# Altium schematic sheet Connector.SchDoc(Connector)
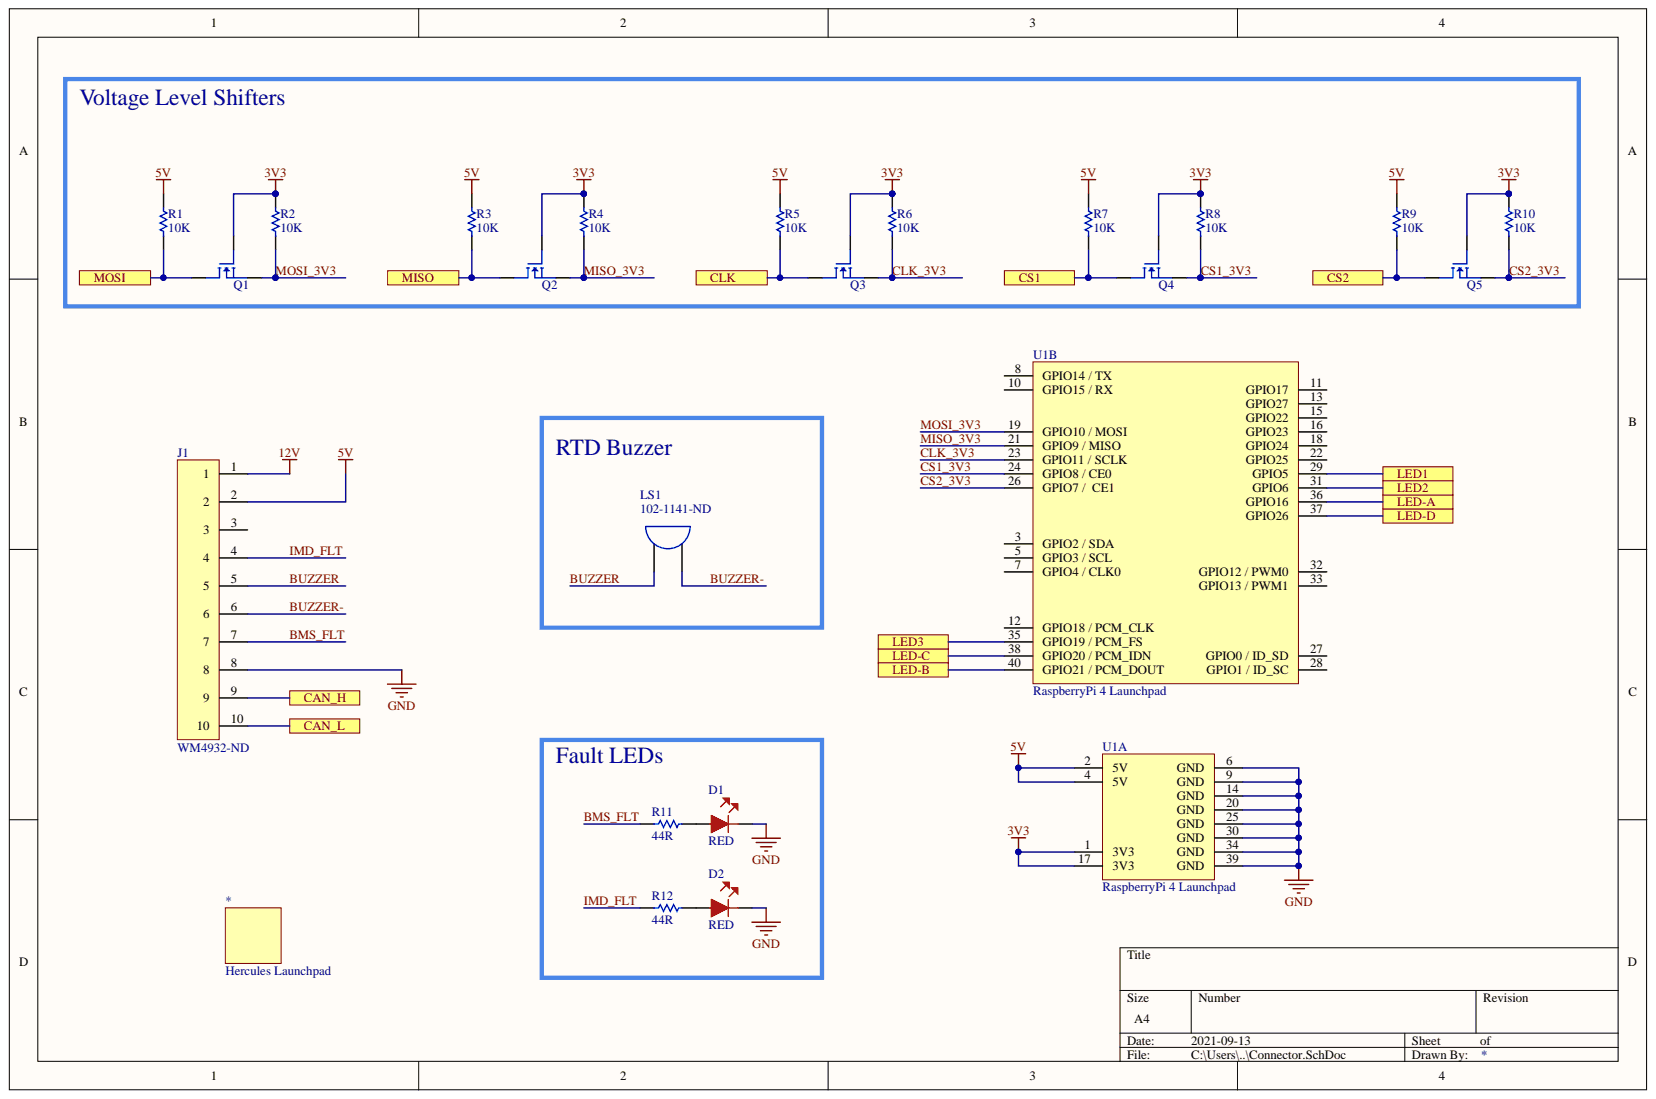
[diagram p0: Connector.SchDoc]

## Parts
*  Hercules Launchpad  [RECEp]
D1  RED  [LED]
D2  RED  [LED]
J1  WM4932-ND  [10PIN]
LS1  102-1141-ND  [Buzzer]
Q1  DMN6140L-13DICT-ND  [SOT-23-3]  (value not drawn)
Q2  DMN6140L-13DICT-ND  [SOT-23-3]  (value not drawn)
Q3  DMN6140L-13DICT-ND  [SOT-23-3]  (value not drawn)
Q4  DMN6140L-13DICT-ND  [SOT-23-3]  (value not drawn)
Q5  DMN6140L-13DICT-ND  [SOT-23-3]  (value not drawn)
R1  10K  [RES-0603]
R2  10K  [RES-0603]
R3  10K  [RES-0603]
R4  10K  [RES-0603]
R5  10K  [RES-0603]
R6  10K  [RES-0603]
R7  10K  [RES-0603]
R8  10K  [RES-0603]
R9  10K  [RES-0603]
R10  10K  [RES-0603]
R11  44R  [RES-0603]
R12  44R  [RES-0603]
U1  RaspberryPi 4 Launchpad  [Rpi Connector]  (parts A,B)

## Ports
CAN_H, CAN_L, CLK, CS1, CS2, LED1, LED2, LED3, LED-A, LED-B, LED-C, LED-D, MISO, MOSI

## Nets
3V3: Q1.1 Q2.1 Q3.1 Q4.1 Q5.1 R2.2 R4.2 R6.2 R8.2 R10.2 U1.1(3V3) U1.17(3V3)
5V: J1.2 R1.2 R3.2 R5.2 R7.2 R9.2 U1.2(5V) U1.4(5V)
12V: J1.1
GND: D1.2 D2.2 J1.8 U1.6(GND) U1.9(GND) U1.14(GND) U1.20(GND) U1.25(GND) U1.30(GND) U1.34(GND) U1.39(GND)
BMS_FLT: J1.7 R11.1
BUZZER: J1.5 LS1.1
BUZZER-: J1.6 LS1.2
CAN_H: J1.9 ; port CAN_H
CAN_L: J1.10 ; port CAN_L
CLK: Q3.2 R5.1 ; port CLK
CLK_3V3: Q3.3 R6.1 U1.23(GPIO11_/_SCLK)
CS1: Q4.2 R7.1 ; port CS1
CS1_3V3: Q4.3 R8.1 U1.24(GPIO8_/_CE0)
CS2: Q5.2 R9.1 ; port CS2
CS2_3V3: Q5.3 R10.1 U1.26(GPIO7_/)
IMD_FLT: J1.4 R12.1
LED1: U1.29(GPIO5) ; port LED1
LED2: U1.31(GPIO6) ; port LED2
LED3: U1.35(GPIO19_/_PCM_FS) ; port LED3
LED-A: U1.36(GPIO16) ; port LED-A
LED-B: U1.40(GPIO21_/_PCM_DOUT) ; port LED-B
LED-C: U1.38(GPIO20_/_PCM_IDN) ; port LED-C
LED-D: U1.37(GPIO26) ; port LED-D
MISO: Q2.2 R3.1 ; port MISO
MISO_3V3: Q2.3 R4.1 U1.21(GPIO9_/_MISO)
MOSI: Q1.2 R1.1 ; port MOSI
MOSI_3V3: Q1.3 R2.1 U1.19(GPIO10_/_MOSI)
NetD1_1: D1.1 R11.2
NetD2_1: D2.1 R12.2

Not connected: J1 3; U1 3(GPIO2_/_SDA) 5(GPIO3_/_SCL) 7(GPIO4_/_CLK0) 8(GPIO14_/_TX) 10(GPIO15_/_RX) 11(GPIO17) 12(GPIO18_/_PCM_CLK) 13(GPIO27) 15(GPIO22) 16(GPIO23) 18(GPIO24) 22(GPIO25) 27(GPIO0_/_ID_SD) 28(GPIO1_/_ID_SC) 32(GPIO12_/_PWM0) 33(GPIO13_/_PWM1)

## Library data of the parts
* = Recep: Component Kind: Standard; Library Name: WorkshopSlib.SchLib
D1 D2 = RED_LED: 2835 PLCC2 RED WLD: 620NM TYP. 1; Manufacturer Part Number: QBHP686-RH; Manufacturer: QT-Brightek; Viewing Angle: 120°; Test Current: 150mA; Lens Transparency: Clear; Lens Style: Flat; Lens Color: Colorless; Forward Voltage: 2.4V; Width: 2.8mm; Supplier Part Number 1: 1516-1369-6-ND; Supplier 1: Digi-Key; Stock 1: 3207; Pricing 1: 1=0.85, 10=0.553, 100=0.3625, 1000=0.2862 (USD); Packaging: Tape and Reel; Mount: Surface Mount; Length: 3.1mm; Height: 1mm; Dominant Wavelength: 620nm; ComponentLink1URL: http://www.qt-brightek.com/datasheet/QBHP686-XXH Series.pdf?src-supplier=Digi-Key; ComponentLink1Description: http://www.qt-brightek.com/datasheet/QBHP686-XXH Series.pdf?src-supplier=Digi-Key; Component Kind: Standard; Color: Red; Case Code (Imperial): 1113; Case/Package: 1113; Library Name: BrakeLight.SchLib
J1 = 10PIN: CONN HEADER R/A 10POS 2.54MM; Manufacturer Part Number: 0705530044; Manufacturer: Molex; Number of Positions: 10; Gender: Male; Contact Resistance: 30mR; Connector Type: Male Pin; Termination: Solder; Supplier Part Number 1: WM4932-ND; Supplier 1: Digi-Key; Stock 1: 1173; Pricing 1: 1=2.61, 10=2.367, 100=2.0288, 500=1.69068, 1000=1.44915, 5000=1.28491 (USD); Pitch: 2.54mm; Packaging: Rail/Tube; Orientation: Right Angle; Number of Rows: 1; Number of Contacts: 10; Mount: Through Hole; Min Operating Temperature: -40°C; Max Operating Temperature: 105°C; Library Name: Connectors.SchLib; Length: 27.95mm; Housing Material: Polyester; Housing Color: Black; Depth: 13.59mm; Contact Plating: Tin; Contact Mating Length: 0.24inch; Contact Material: Bronze; ComponentLink1URL: http://www.molex.com/webdocs/datasheets/pdf/en-us/0705530044_PCB_HEADERS.pdf?src-supplier=Avnet; ComponentLink1Description: http://www.molex.com/webdocs/datasheets/pdf/en-us/0705530044_PCB_HEADERS.pdf?src-supplier=Avnet; Component Kind: Standard; Color: Black
LS1 = Buzzer: AUDIO PIEZO TRANSDUCER 30V TH; Manufacturer: CUI; Washable: No; Voltage Rating: 10V; Self Resonant Frequency: 2kHz; Operating Supply Current: 8mA; Capacitance: 28nF; Termination: PC Pins; Supplier Part Number 1: 102-1141-ND; Supplier 1: Digi-Key; Stock 1: 11267; Pricing 1: 1=1.91, 10=1.588, 25=1.468, 50=1.3806, 100=1.2963, 500=1.11268, 1000=1.0621 (USD); Packaging: Bulk; Mount: Through Hole; Min Operating Temperature: -30°C; Max Operating Temperature: 85°C; Manufacturer Part Number: CEP-1116; Library Name: Misc.SchLib; Lead Free: Lead Free; Height: 10.5mm; Diameter: 30mm; Component Kind: Standard; Case/Package: Radial
Q1-Q5 = N-FET-60V-1.6A: MOSFET N-CH 60V 1.6A SOT23; Manufacturer: Diodes; Rds On Max: 140mR; Max Power Dissipation: 700mW; Input Capacitance: 315pF; Supplier Part Number 1: DMN6140L-13DIDKR-ND; Supplier 1: Digi-Key; Stock 1: 274948; Pricing 1: 1=0.34, 10=0.256, 25=0.224, 100=0.1217, 250=0.12104, 500=0.09926, 1000=0.07365, 2500=0.06468, 5000=0.05924 (USD); Packaging: Cut Tape; Package Quantity: 10000; Mount: Surface Mount; Min Operating Temperature: -55°C; Max Operating Temperature: 150°C; Manufacturer Part Number: DMN6140L-13; Library Name: Transistors.SchLib; Drain to Source Voltage (Vdss): 60V; Continuous Drain Current (ID): 1.6A; ComponentLink1URL: https://www.diodes.com/assets/Datasheets/DMN6140L.pdf?src-supplier=Digi-Key; ComponentLink1Description: https://www.diodes.com/assets/Datasheets/DMN6140L.pdf?src-supplier=Digi-Key; Component Kind: Standard; Case/Package: TO-236-3
R1-R10 = 0603-10KR: RES SMD 10K OHM 5% 1/10W 0603; Manufacturer Part Number: RC0603JR-0710KL; Manufacturer: Yageo; Voltage Rating (DC): 50V; Temperature Coefficient: 100ppm/°C; Resistance: 10kR; Power Rating: 100mW; Width: 0.031inch; Voltage Rating: 50V; Tolerance: 5%; Termination: SMD/SMT; Supplier Part Number 1: 311-10KGRDKR-ND; Supplier 1: Digi-Key; Stock 1: 3006558; REACH SVHC: Unknown; Radiation Hardening: No; Pricing 1: 1=0.1, 10=0.019, 100=0.0077, 1000=0.00347, 2500=0.00301 (USD); Packaging: Tape and Reel; Number of Terminations: 2; Number of Pins: 2; Min Operating Temperature: -55°C; Military Standard: Not; Max Power Dissipation: 100mW; Max Operating Temperature: 155°C; Library Name: Resistors.SchLib; Length: 0.063inch; Lead Free: Lead Free; Height - Seated (Max): 0.022inch; Features: Moisture Resistant; Depth: 0.8mm; Contact Plating: Tin,Matte; Composition: Thick Film; ComponentLink1URL: http://www.yageo.com/documents/recent/PYu-RC_Group_51_RoHS_L_10.pdf?src-supplier=Digi-Key; ComponentLink1Description: http://www.yageo.com/documents/recent/PYu-RC_Group_51_RoHS_L_10.pdf?src-supplier=Digi-Key; Component Kind: Standard; Case Code (Metric): 1608; Case Code (Imperial): 0603; Case/Package: 0603
R11 R12 = 0603-44R: Manufacturer Part Number: ERJ-3EKF44R2V; Manufacturer: Panasonic; Voltage Rating (DC): 50V; Voltage Rating: 75V; Tolerance: 1%; Temperature Coefficient: 100ppm/°C; Resistance: 44.2R; Power Rating: 100mW; Width: 0.8mm; Weight: 7.1E-05oz; Termination: SMD/SMT; Supplier Part Number 1: P44.2HDKR-ND; Supplier 1: Digi-Key; Stock 1: 31052; Series: ERJ; RoHS Compliant: Yes; Resistor Type: Thick Film; Resistance Tolerance: 1%; REACH SVHC: No SVHC; Pricing 1: 1=0.1, 10=0.037, 100=0.0149, 1000=0.0067, 2500=0.00582 (USD); Packaging: Tape and Reel; Package Quantity: 1; Number of Terminations: 2; Mount: Surface Mount; Min Operating Temperature: -55°C; Military Standard: Not; Max Power Dissipation: 100mW; Max Operating Temperature: 155°C; Length: 1.6mm; Height: 0.022inch; Features: Automotive AEC-Q200; Depth: 0.8mm; Contact Plating: Tin; Construction: Rectangular; ComponentLink3URL: http://www.farnell.com/datasheets/426256.pdf?src-supplier=Element14; ComponentLink3Description: http://www.farnell.com/datasheets/426256.pdf?src-supplier=Element14; ComponentLink2URL: http://industrial.panasonic.com/cdbs/www-data/pdf/RDA0000/AOA0000C304.pdf?src-supplier=Farnell; ComponentLink2Description: http://industrial.panasonic.com/cdbs/www-data/pdf/RDA0000/AOA0000C304.pdf?src-supplier=Farnell; ComponentLink1URL: http://industrial.panasonic.com/www-data/pdf/AOA0000/AOA0000CE2.pdf?src-supplier=Avnet; ComponentLink1Description: http://industrial.panasonic.com/www-data/pdf/AOA0000/AOA0000CE2.pdf?src-supplier=Avnet; Component Kind: Standard; Case Code (Metric): 1608; Case Code (Imperial): 0603; Case/Package: 0603; Library Name: BrakeLight.SchLib
U1 = RasPi4: CONNECTOR DEV BOARD RaspberryPi 4; Manufacturer Part Number: PPPC202LFBN-RC; Manufacturer: Sullins; Row Spacing: 0.1inch; Number of Positions: 40; Connector Type: Header; Width: 5mm; Termination: Solder; Supplier Part Number 1: S7123-ND; Supplier 1: Digi-Key; Stock 1: 10499; RoHS Compliant: Yes; Pricing 1: 1=2.38, 10=2.068, 80=1.84838, 440=1.54034, 1000=1.3203, 5000=1.1736 (USD); Pitch: 0.1inch; Packaging: Bulk; Package Quantity: 1000; Number of Rows: 2; Mount: Through Hole; Length: 51.3mm; Lead Free: Lead Free; Housing Material: Plastic; Height: 8.5mm; Contact Type: Female Socket; Contact Plating: Gold; Contact Material: Bronze; Component Kind: Standard; Color: Black; Library Name: WorkshopSlib.SchLib
